# Altium schematic sheet 1-PSU-inputs.SchDoc
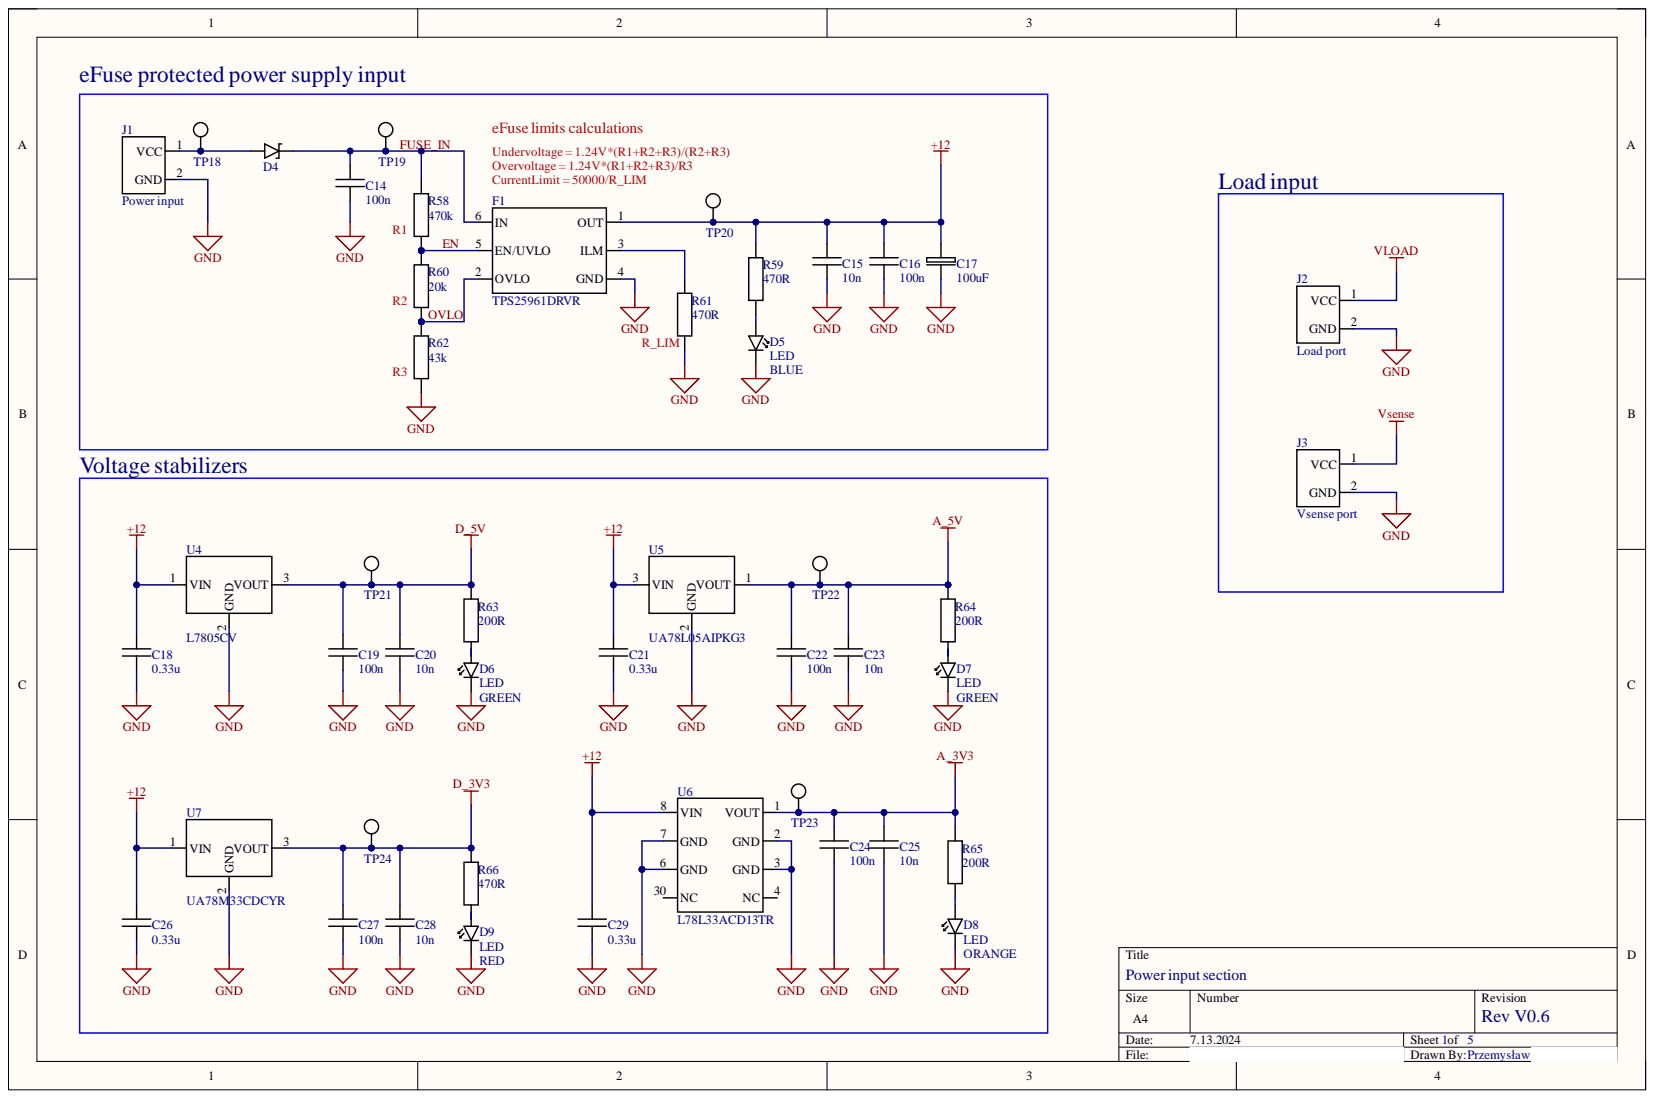
[diagram p0: 1-PSU-inputs.SchDoc]

## Parts
C14  100n  [0805 Capacitor]
C15  10n  [0805 Capacitor]
C16  100n  [0805 Capacitor]
C17  100uF  [CAP_D]
C18  0.33u  [0805 Capacitor]
C19  100n  [0805 Capacitor]
C20  10n  [0805 Capacitor]
C21  0.33u  [0805 Capacitor]
C22  100n  [0805 Capacitor]
C23  10n  [0805 Capacitor]
C24  100n  [0805 Capacitor]
C25  10n  [0805 Capacitor]
C26  0.33u  [0805 Capacitor]
C27  100n  [0805 Capacitor]
C28  10n  [0805 Capacitor]
C29  0.33u  [0805 Capacitor]
D4  PMEG2020EPK  [PMEG2020EPK315]  (value not drawn)
D5  LED  Colour: BLUE  [DIOM1608X08M]
D6  LED  Colour: GREEN  [DIOM1608X08M]
D7  LED  Colour: GREEN  [DIOM1608X08M]
D8  LED  Colour: ORANGE  [DIOM1608X08M]
D9  LED  Colour: RED  [DIOM1608X08M]
F1  TPS25961DRVR  [PSON65P200X80_HS-7N]
J1  Power input  [1869062]
J2  Load port  [1869062]
J3  Vsense port  [1869062]
R58  470k  [0805 Resistor]
R59  470R  [1206 Resistor]
R60  20k  [0805 Resistor]
R61  470R  [1206 Resistor]
R62  43k  [0805 Resistor]
R63  200R  [0805 Resistor]
R64  200R  [0805 Resistor]
R65  200R  [0805 Resistor]
R66  470R  [1206 Resistor]
TP18  Test Point  [050LTB2]  (value not drawn)
TP19  Test Point  [050LTB2]  (value not drawn)
TP20  Test Point  [050LTB2]  (value not drawn)
TP21  Test Point  [050LTB2]  (value not drawn)
TP22  Test Point  [050LTB2]  (value not drawn)
TP23  Test Point  [050LTB2]  (value not drawn)
TP24  Test Point  [050LTB2]  (value not drawn)
U4  L7805CV  [TO255P460X1020X2008-3P]
U5  UA78L05AIPKG3  [SOT223127P600-8N]
U6  L78L33ACD13TR  [SOIC8N]
U7  UA78M33CDCYR  [SOT223-UA78M]

## Nets
+12: C15.2 C16.2 C17.2 C18.2 C21.2 C26.2 C29.2 F1.1(OUT) R59.2 TP20.1 U4.1 U5.3 U6.8(VIN) U7.1
A_3V3: C24.2 C25.2 R65.2 TP23.1 U6.1(VOUT)
A_5V: C22.2 C23.2 R64.2 TP22.1 U5.1
D_3V3: C27.2 C28.2 R66.2 TP24.1 U7.3
D_5V: C19.2 C20.2 R63.2 TP21.1 U4.3
GND: C14.1 C15.1 C16.1 C17.1 C18.1 C19.1 C20.1 C21.1 C22.1 C23.1 C24.1 C25.1 C26.1 C27.1 C28.1 C29.1 D5.2 D6.2 D7.2 D8.2 D9.2 F1.4(GND) J1.2 J2.2 J3.2 R61.1 R62.1 U4.2 U5.2 U6.2(GND) U6.3(GND) U6.6(GND) U6.7(GND) U7.2
VLOAD: J2.1
Vsense: J3.1
EN: F1.5(EN/UVLO) R58.1 R60.2
FUSE_IN: C14.2 D4.2 F1.6(IN) R58.2 TP19.1
OVLO: F1.2(OVLO) R60.1 R62.2
NetD4_1: D4.1 J1.1 TP18.1
NetD5_1: D5.1 R59.1
NetD6_1: D6.1 R63.1
NetD7_1: D7.1 R64.1
NetD8_1: D8.1 R65.1
NetD9_1: D9.1 R66.1
NetF1_3: F1.3(ILM) R61.2

Not connected: U6 4(NC) 30(NC)

## Library data of the parts
C14 C16 C19 C22 C24 C27 = 100nF Capacitor: 10% 0805 50VDC; Component Kind: Standard; Library Name: Capacitor_LIB.SchLib
C15 C20 C23 C25 C28 = 10nF Capacitor: 10% 0805 100VDC; Component Kind: Standard; Library Name: Capacitor_LIB.SchLib
C17 = 100uF Capacitor: Component Kind: Standard; Library Name: Capacitor_LIB.SchLib
C18 C21 C26 C29 = 0.33uF Capacitor: 10% 0805 50VDC; Component Kind: Standard; Library Name: Capacitor_LIB.SchLib
D4 = PMEG2020EPK: Schotttky diode; Component Kind: Standard; Library Name: DIODE_LIB.SchLib
F1 = TPS25961DRVR: eFuse; Component Kind: Standard; Library Name: LOAD_SCH_LIB.SchLib
J1-J3 = Screw terminal: 2 hole screw connector; Component Kind: Standard; Library Name: LOAD_SCH_LIB.SchLib
R58 = 470K Resistor: 1% 0805 1/8W; Component Kind: Standard; Library Name: Resistor_LIB.SchLib
R59 R61 R66 = 470R Resistor: 1% 1206 1/4W; Component Kind: Standard; Library Name: Resistor_LIB.SchLib
R60 = 20K Resistor: 1% 0805 1/8W; Component Kind: Standard; Library Name: Resistor_LIB.SchLib
R62 = 43K Resistor: 1% 0805 1/8W; Component Kind: Standard; Library Name: Resistor_LIB.SchLib
R63-R65 = 200R Resistor: 1% 0805 1/8W; Component Kind: Standard; Library Name: Resistor_LIB.SchLib
TP18-TP24 = TestPoint: Component Kind: Standard; Description: Test Point; Library Name: LOAD_SCH_LIB.SchLib
U4 = L7805CV: 5V 1A voltage regulator; Component Kind: Standard; Library Name: LOAD_SCH_LIB.SchLib
U5 = UA78L05AIPKG3: 5V 100mA voltage regulator; Component Kind: Standard; Library Name: LOAD_SCH_LIB.SchLib
U6 = L78L33ACD13TR: 3v3 100mA voltage regulator; Component Kind: Standard; Library Name: LOAD_SCH_LIB.SchLib
U7 = UA78M33CDCYR: 3.3V 500mA voltage regulator; Component Kind: Standard; Library Name: LOAD_SCH_LIB.SchLib
D5-D9 = LED: Component Kind: Standard; Description: LED; Library Name: DIODE_LIB.SchLib
